# Altium schematic sheet Sch-QWA59_LAN.SchDoc
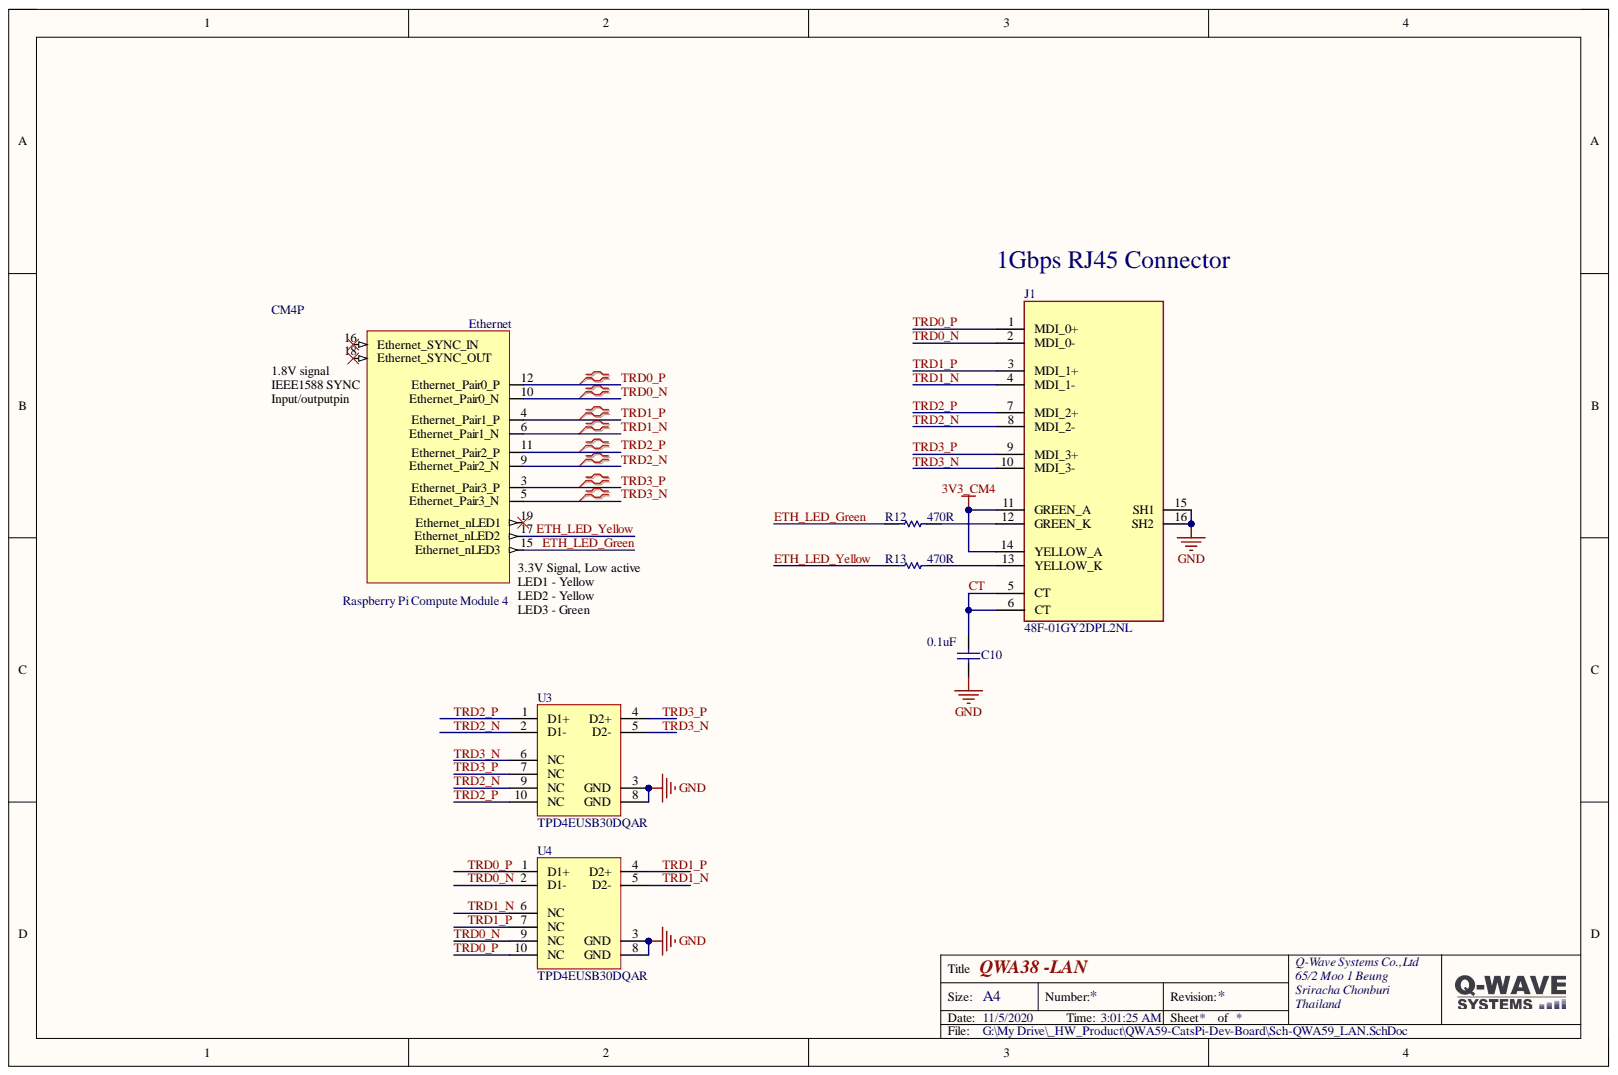
[diagram p0: Sch-QWA59_LAN.SchDoc]

## Parts
C10  0.1uF  [CAP_0603]
CM4  Raspberry Pi Compute Module 4  [CM4-F40C-100DS-0.4v_1.5mm_0mm]  (part P)
J1  48F-01GY2DPL2NL  [48F-01GY2DPL2NL]
R12  470R  [RES_0603]
R13  470R  [RES_0603]
U3  TPD4EUSB30DQAR  [DQA0010A_V]
U4  TPD4EUSB30DQAR  [DQA0010A_V]

## Nets
3V3_CM4: J1.11(GREEN_A) J1.14(YELLOW_A)
GND: C10.1 J1.15(SH1) J1.16(SH2) U3.3(GND) U3.8(GND) U4.3(GND) U4.8(GND)
CT: C10.2 J1.5(CT) J1.6(CT)
ETH_LED_Green: CM4.15(Ethernet_nLED3) R12.1
ETH_LED_Yellow: CM4.17(Ethernet_nLED2) R13.1
TRD0_N: CM4.10(Ethernet_Pair0_N) J1.2(MDI_0-) U4.2(D1-) U4.9(NC)
TRD0_P: CM4.12(Ethernet_Pair0_P) J1.1(MDI_0+) U4.1(D1+) U4.10(NC)
TRD1_N: CM4.6(Ethernet_Pair1_N) J1.4(MDI_1-) U4.5(D2-) U4.6(NC)
TRD1_P: CM4.4(Ethernet_Pair1_P) J1.3(MDI_1+) U4.4(D2+) U4.7(NC)
TRD2_N: CM4.9(Ethernet_Pair2_N) J1.8(MDI_2-) U3.2(D1-) U3.9(NC)
TRD2_P: CM4.11(Ethernet_Pair2_P) J1.7(MDI_2+) U3.1(D1+) U3.10(NC)
TRD3_N: CM4.5(Ethernet_Pair3_N) J1.10(MDI_3-) U3.5(D2-) U3.6(NC)
TRD3_P: CM4.3(Ethernet_Pair3_P) J1.9(MDI_3+) U3.4(D2+) U3.7(NC)
NetJ1_12: J1.12(GREEN_K) R12.2
NetJ1_13: J1.13(YELLOW_K) R13.2

Not connected: CM4 16(Ethernet_SYNC_IN) 18(Ethernet_SYNC_OUT) 19(Ethernet_nLED1)

## Library data of the parts
C10 = Cap Semi: 100nF ±5% 50V Ceramic Capacitor X7R 0603; MFG: KEMET; Component Kind: Standard; Library Name: Miscellaneous Devices.IntLib; Signal Integrity: Cap Semi; Simulation: CAP
CM4 = CM4: Raspberry Pi Compute Module 4 by Amornthep Phunsin; Component Kind: Standard; Library Name: CM4.SchLib
J1 = 48F-01GY2DPL2NL: Shanghai YDS Tech  48F-01GY2DPL2NL  Ethernet Connectors/Modular Connectors; Manufacturer_Name: Hanrun Electronics; Manufacturer_Part_Number: HY911130A; Component Kind: Standard; Datasheet Link: https://datasheet.lcsc.com/szlcsc/1000M-1-1-With-lights_C55679.pdf; Height: 13.5mm; Library Name: QWA59.SchLib
R12 R13 = Res Semi: Resistor 0603; Component Kind: Standard; Library Name: Miscellaneous Devices.IntLib; Signal Integrity: Res Semi; Simulation: RES
U3 U4 = CMP-0326-00027-3: ESD Solution for Super-Speed (6 GBPS) USB 3.0 Interface, 4 Channels, -40 to +85 degC, 10-pin SON (DQA), Green (RoHS & no Sb/Br); Manufacturer: Texas Instruments; 1394 / Firewire: TRUE; Breakdown Voltage(Min)(V): 8; Differential Capacitance(pF): 0.05; IO Capacitance(Typ)(pF): 0.8; IO Leakage Current(nA): 10; Component Kind: Standard; ComponentLink1Description: Manufacturer URL; ComponentLink1URL: http://www.ti.com/; ComponentLink2Description: Datasheet; ComponentLink2URL: http://www.ti.com/general/docs/lit/getliterature.tsp?genericPartNumber=TPD4EUSB30&fileType=pdf; ComponentLink3Description: URL Link1; ComponentLink3URL: SamplesURL:http://www.ti.com/product/tpd4eusb30#samples; DatasheetVersion: SLVSAC2C; Eco-Plan: Green (RoHS & no Sb/Br); HDMI: TRUE; IEC 61000-4-2 Air-Gap(+/- kV): 8; IEC 61000-4-2 Contact(+/- kV): 8; Library Name: Altium Content Vault; Mounting Technology: Surface Mount; PackageDescription: 10-Pin Plastic Small Outline No-Lead, 1 x 2.5 x 0.55 mm Body, 0.5 mm Pitch; PackageReference: DQA0010A; PackageVersion: revB, Sep-2009; PartNumber: TPD4EUSB30DQAR; Serial ATA / eSATA: TRUE; SubFamily: ESD Solution; USB Full Speed: TRUE; USB High Speed: TRUE; USB Super Speed: TRUE
